# Altium schematic sheet GammaMRICAB_2 - MIO Ethernet.SchDoc
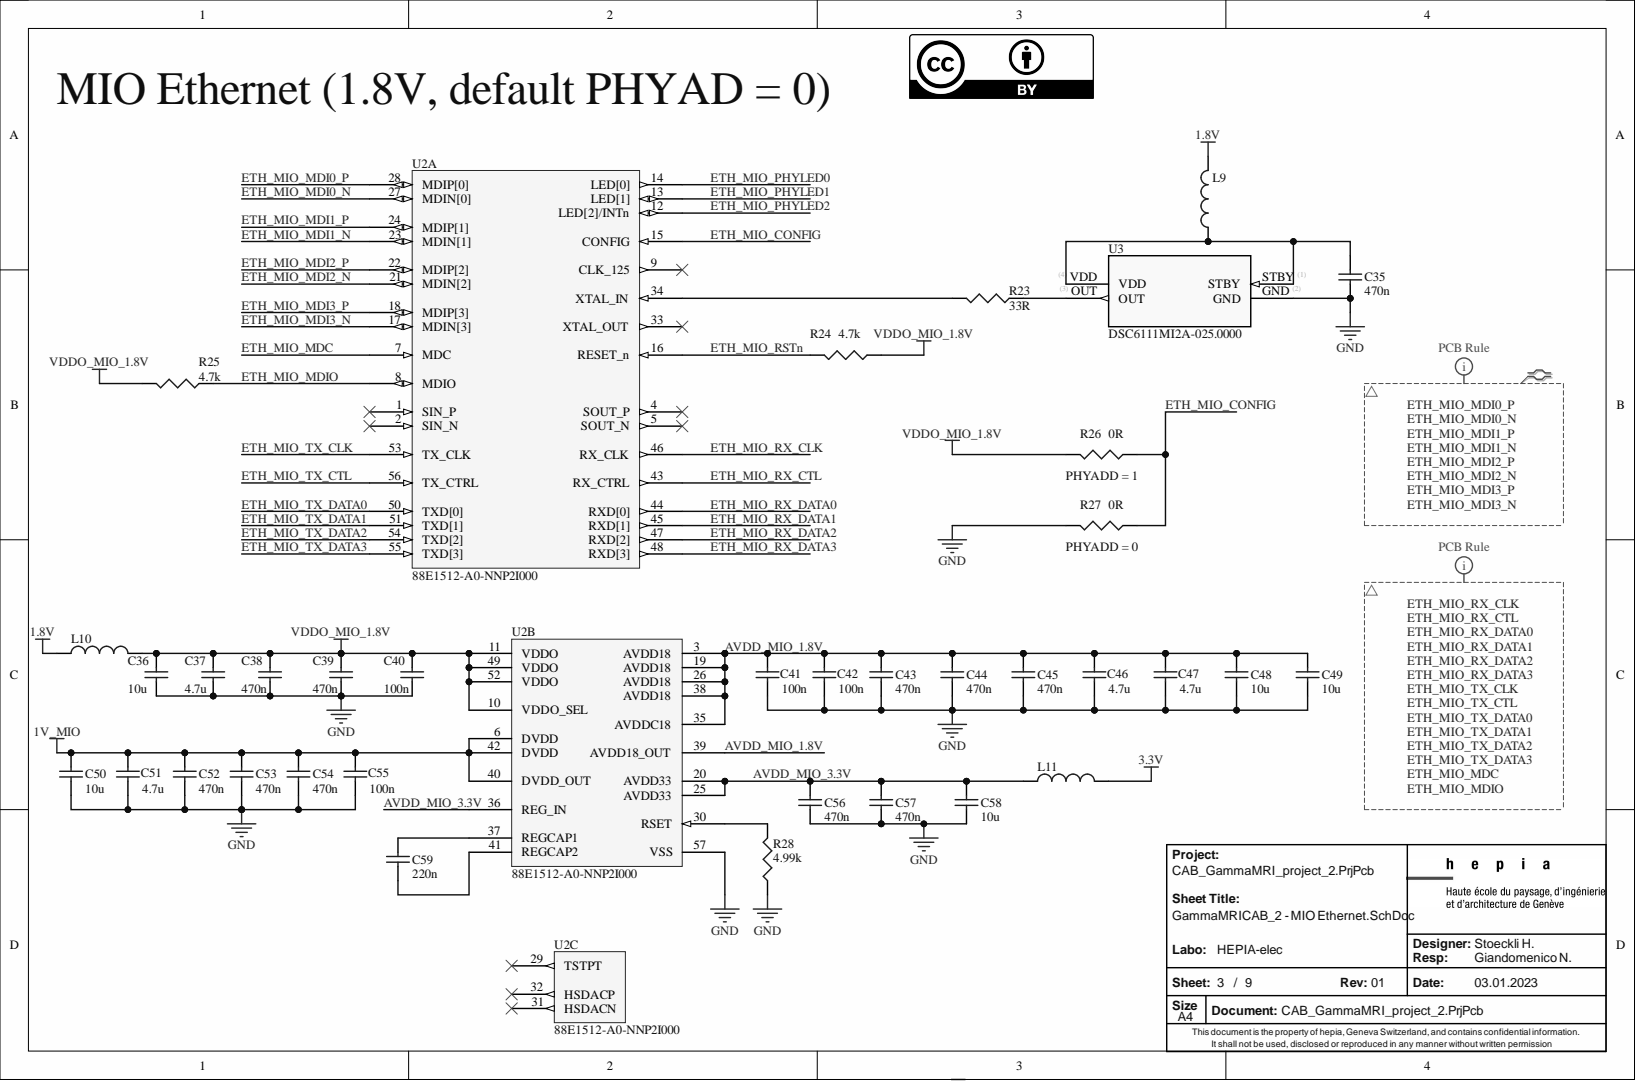
[diagram p0: GammaMRICAB_2 - MIO Ethernet.SchDoc]

## Parts
C35  470n  [0402]
C36  10u  [1608[0603]]
C37  4.7u  [0402]
C38  470n  [0402]
C39  470n  [0402]
C40  100n  [0402]
C41  100n  [0402]
C42  100n  [0402]
C43  470n  [0402]
C44  470n  [0402]
C45  470n  [0402]
C46  4.7u  [0402]
C47  4.7u  [0402]
C48  10u  [1608[0603]]
C49  10u  [1608[0603]]
C50  10u  [1608[0603]]
C51  4.7u  [0402]
C52  470n  [0402]
C53  470n  [0402]
C54  470n  [0402]
C55  100n  [0402]
C56  470n  [0402]
C57  470n  [0402]
C58  10u  [1608[0603]]
C59  220n  [0402]
L9  BKP0603HS121-T  [0603]  (value not drawn)
L10  BKP0603HS121-T  [0603]  (value not drawn)
L11  BKP0603HS121-T  [0603]  (value not drawn)
R23  33R  [0402]
R24  4.7k  [0402]
R25  4.7k  [0402]
R26  0R  [0402]
R27  0R  [0402]
R28  4.99k  [0402]
U2  88E1512-A0-NNP2I000  [QFN40P700X700X80-57N]  (parts A,B,C)
U3  DSC6111MI2A-025.0000  [DSC6111MI2A-025.0000-ND]

## Nets
1.8V: L9.2 L10.1
1V_MIO: C50.2 C51.2 C52.2 C53.2 C54.2 C55.2 U2.6(DVDD) U2.40(DVDD_OUT) U2.42(DVDD)
3.3V: L11.2
GND: C35.1 C36.1 C37.1 C38.1 C39.1 C40.1 C41.1 C42.1 C43.1 C44.1 C45.1 C46.1 C47.1 C48.1 C49.1 C50.1 C51.1 C52.1 C53.1 C54.1 C55.1 C56.1 C57.1 C58.1 R27.2 R28.1 U2.57(VSS) U3.2(GND)
VDDO_MIO_1.8V: C36.2 C37.2 C38.2 C39.2 C40.2 L10.2 R24.1 R25.2 R26.2 U2.10(VDDO_SEL) U2.11(VDDO) U2.49(VDDO) U2.52(VDDO)
AVDD_MIO_1.8V: C41.2 C42.2 C43.2 C44.2 C45.2 C46.2 C47.2 C48.2 C49.2 U2.3(AVDD18) U2.19(AVDD18) U2.26(AVDD18) U2.35(AVDDC18) U2.38(AVDD18) U2.39(AVDD18_OUT)
AVDD_MIO_3.3V: C56.2 C57.2 C58.2 L11.1 U2.20(AVDD33) U2.25(AVDD33) U2.36(REG_IN)
ETH_MIO_CONFIG: R26.1 R27.1 U2.15(CONFIG)
ETH_MIO_MDC: U2.7(MDC)
ETH_MIO_MDI0_N: U2.27(MDIN[0])
ETH_MIO_MDI0_P: U2.28(MDIP[0])
ETH_MIO_MDI1_N: U2.23(MDIN[1])
ETH_MIO_MDI1_P: U2.24(MDIP[1])
ETH_MIO_MDI2_N: U2.21(MDIN[2])
ETH_MIO_MDI2_P: U2.22(MDIP[2])
ETH_MIO_MDI3_N: U2.17(MDIN[3])
ETH_MIO_MDI3_P: U2.18(MDIP[3])
ETH_MIO_MDIO: R25.1 U2.8(MDIO)
ETH_MIO_PHYLED0: U2.14(LED[0])
ETH_MIO_PHYLED1: U2.13(LED[1])
ETH_MIO_PHYLED2: U2.12(LED[2]/INTn)
ETH_MIO_RSTn: R24.2 U2.16(RESET_n)
ETH_MIO_RX_CLK: U2.46(RX_CLK)
ETH_MIO_RX_CTL: U2.43(RX_CTRL)
ETH_MIO_RX_DATA0: U2.44(RXD[0])
ETH_MIO_RX_DATA1: U2.45(RXD[1])
ETH_MIO_RX_DATA2: U2.47(RXD[2])
ETH_MIO_RX_DATA3: U2.48(RXD[3])
ETH_MIO_TX_CLK: U2.53(TX_CLK)
ETH_MIO_TX_CTL: U2.56(TX_CTRL)
ETH_MIO_TX_DATA0: U2.50(TXD[0])
ETH_MIO_TX_DATA1: U2.51(TXD[1])
ETH_MIO_TX_DATA2: U2.54(TXD[2])
ETH_MIO_TX_DATA3: U2.55(TXD[3])
NetC35_2: C35.2 L9.1 U3.1(STBY) U3.4(VDD)
NetC59_1: C59.1 U2.41(REGCAP2)
NetC59_2: C59.2 U2.37(REGCAP1)
NetR23_1: R23.1 U3.3(OUT)
NetR23_2: R23.2 U2.34(XTAL_IN)
NetR28_2: R28.2 U2.30(RSET)

Not connected: U2 1(SIN_P) 2(SIN_N) 4(SOUT_P) 5(SOUT_N) 9(CLK_125) 29(TSTPT) 31(HSDACN) 32(HSDACP) 33(XTAL_OUT)

## Library data of the parts
C35 C38 C39 C43-C45 C52-C54 C56 C57 = Cap: Capacitor 470nF C0402 X5R 6.3V; Component Kind: Standard; Library Name: Miscellaneous Devices.IntLib; Signal Integrity: Cap; Simulation: CAP; Supplier1: Digikey; Supplier1PN1: 311-1684-6-ND
C36 C48-C50 C58 = Cap: Capacitor 10uF C0603 X6S 16V; Component Kind: Standard; Library Name: Miscellaneous Devices.IntLib; Signal Integrity: Cap; Simulation: CAP; Supplier1: Digikey; Supplier1PN1: 490-14637-6-ND
C37 C46 C47 C51 = Cap: Capacitor 4.7uF C0402 X5R 6.3V; Component Kind: Standard; Library Name: Miscellaneous Devices.IntLib; Signal Integrity: Cap; Simulation: CAP; Supplier1: Digikey; Supplier1PN1: 445-7395-1-ND
C40-C42 C55 = Cap: Capacitor 100nF C0402 X5R 6.3V; Component Kind: Standard; Library Name: Miscellaneous Devices.IntLib; Signal Integrity: Cap; Simulation: CAP; Supplier1: Digikey; Supplier1PN1: 490-6287-6-ND
C59 = Cap: Capacitor 220nF C0402 X5R 6.3V; Component Kind: Standard; Library Name: Miscellaneous Devices.IntLib; Signal Integrity: Cap; Simulation: CAP; Supplier1: Digikey; Supplier1PN1: 478-1132-1-ND
L9-L11 = Inductor: Ferrite 0201 120ohms@100MHz; Component Kind: Standard; Library Name: Miscellaneous Devices.IntLib; Signal Integrity: Inductor; Simulation: INDUCTOR; Supplier1: Digikey; Supplier1PN1: 490-BLM03PX121SN1DDKR-ND
R23 = Res3: Resistor 33Ohm 1% 0402; Component Kind: Standard; Library Name: Miscellaneous Devices.IntLib; Signal Integrity: Res; Simulation: RESISTOR; Supplier1: Digikey; Supplier1PN1: A129609CT-ND
R24 R25 = Res3: Resistor 4.7kOhm 1% 0402; Component Kind: Standard; Library Name: Miscellaneous Devices.IntLib; Signal Integrity: Res; Simulation: RESISTOR; Supplier1: Digikey; Supplier1PN1: 311-4.7KLRCT-ND‎
R26 R27 = Res3: Resistor 0Ohm 0402; Component Kind: Standard; Library Name: Miscellaneous Devices.IntLib; Signal Integrity: Res; Simulation: RESISTOR; Supplier1: Digikey; Supplier1PN1: RMCF0402ZT0R00CT-ND‎
R28 = Res3: Resistor 4.99kOhm 1% 0402; Component Kind: Standard; Library Name: Miscellaneous Devices.IntLib; Signal Integrity: Res; Simulation: RESISTOR; Supplier1: Digikey; Supplier1PN1: 311-4.99KLRCT-ND
U2 = 88E1512-A0-NNP2I000: Ethernet Transceiver 1000Mb/s RGMII; Component Kind: Standard; Supplier1: Digikey; Supplier1PN1: 2208-88E1512-A0-NNP2I000-ND; Library Name: CAB_SCH_LIB.SchLib
U3 = DSC6111MI2A-025.: No Description Available; Component Kind: Standard; Library Name: DSC6111MI2A-025.0000.SchLib; Supplier1: Digikey; Supplier1PN1: DSC6111MI2A-025.0000
